# Altium schematic sheet 20210515-power.SchDoc
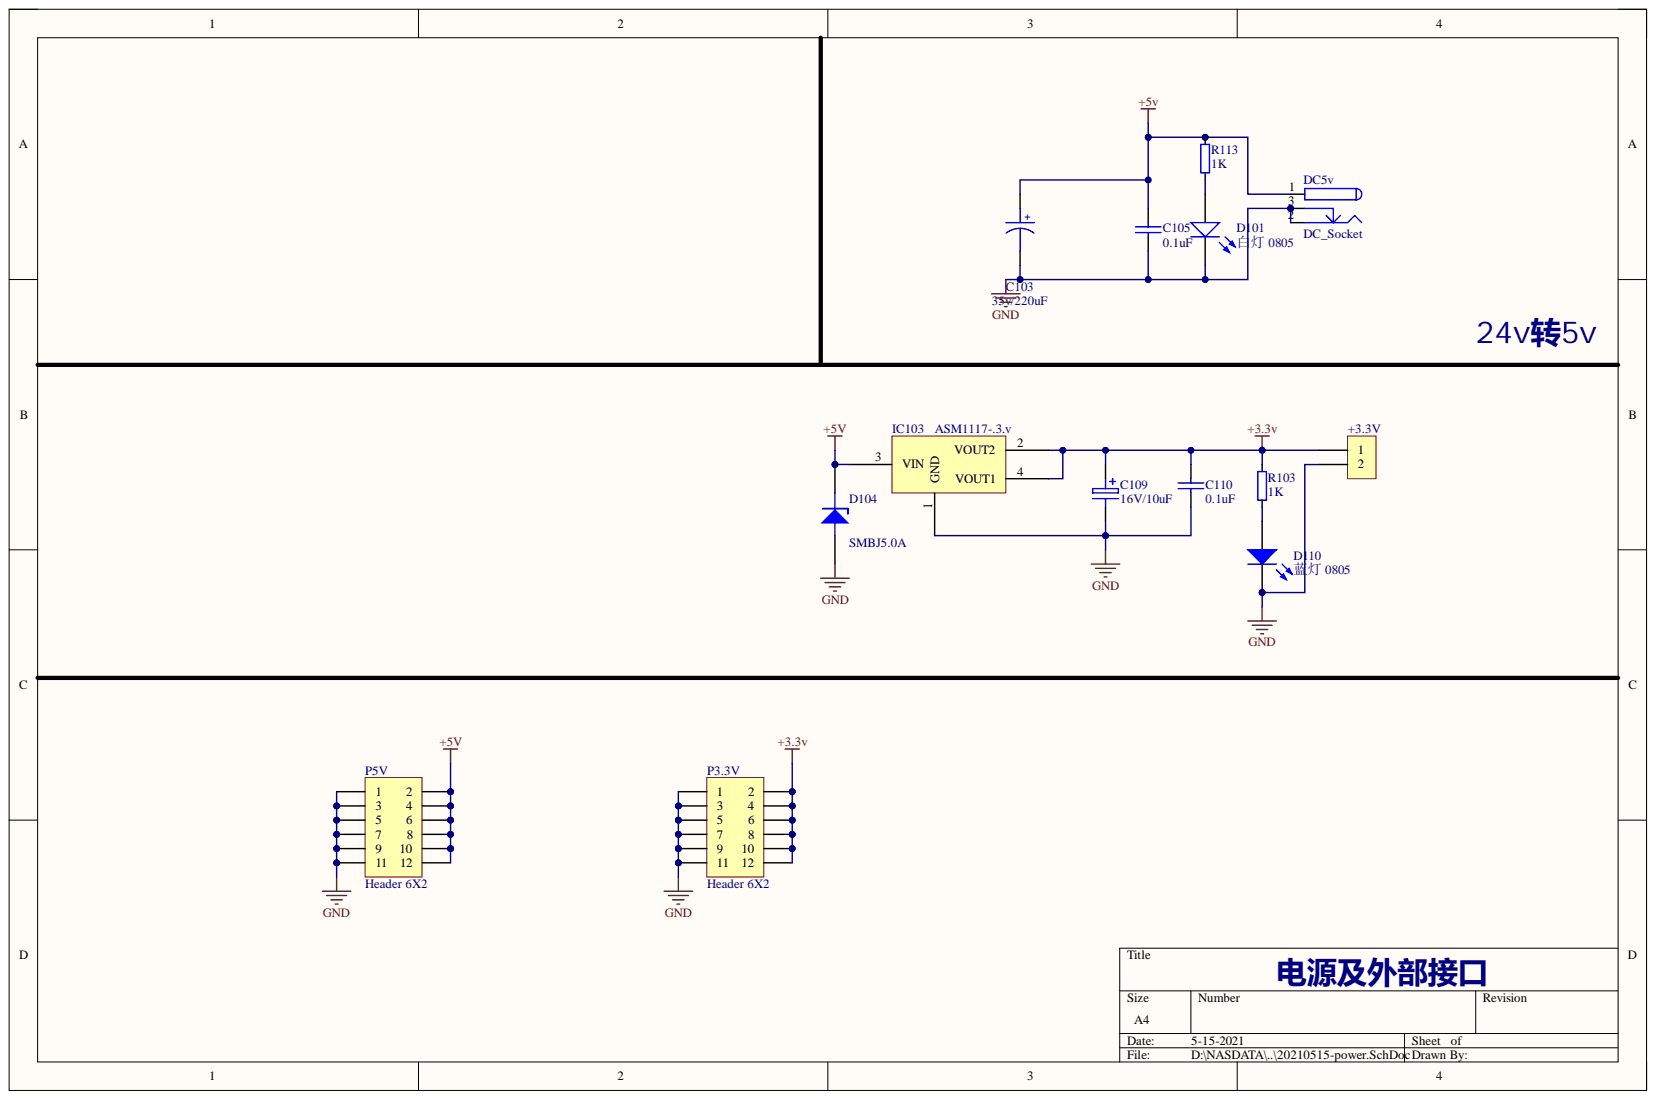
[diagram p0: 20210515-power.SchDoc]

## Parts
+3.3V  (no value)  [XH2/2.54mm/90]
C103  35v/220uF  [SMD-EC8X8X10]
C105  0.1uF  [C0805]
C109  16V/10uF  [SMD-EC6.3X6.3X10]
C110  0.1uF  [C0805]
D101  白灯 0805  [0805_LED_S1]
D104  SMBJ5.0A  [SMB]
D110  蓝灯 0805  [0805_LED_S1]
DC5v  DC_Socket  [DC-002]
IC103  ASM1117-.3.v  [SOT223]
P3.3V  Header 6X2  [HDR2X6]
P5V  Header 6X2  [HDR2X6]
R103  1K  [R0805]
R113  1K  [R0805]

## Nets
+3.3v: +3.3V.1 C109.1 C110.1 IC103.2(VOUT2) IC103.4(VOUT1) P3.3V.2 P3.3V.4 P3.3V.6 P3.3V.8 P3.3V.10 P3.3V.12 R103.2
+5v: C103.1 C105.1 D104.2 DC5v.1 IC103.3(VIN) P5V.2 P5V.4 P5V.6 P5V.8 P5V.10 P5V.12 R113.2
GND: +3.3V.2 C103.2 C105.2 C109.2 C110.2 D101.K D104.1 D110.K DC5v.2 DC5v.3 IC103.1(GND) P3.3V.1 P3.3V.3 P3.3V.5 P3.3V.7 P3.3V.9 P3.3V.11 P5V.1 P5V.3 P5V.5 P5V.7 P5V.9 P5V.11
NetD101_A: D101.A R113.1
NetD110_A: D110.A R103.1

## Library data of the parts
+3.3V = CON2: Connector; Component Kind: Standard; Library Name: 插件.SCHLIB
C103 = 电解电容: Component Kind: Standard; Library Name: LX.SchLib
C105 C110 = 贴片电容: Component Kind: Standard; Library Name: LX.SchLib
C109 = 钽电容: Component Kind: Standard; Library Name: LX.SchLib
D101 = 0805 白灯: 发光二极管; Component Kind: Standard; ComponentLink1Description: 供应商链接; ComponentLink1URL: http://www.szlcsc.com/product/details_35468.html; Library Name: Miscellaneous Devices LC.IntLib; Package: 0805; Supplier: LC; SuppliersPartNumber: C34499
D104 = 贴片稳压二极管: Component Kind: Standard; Library Name: LX.SchLib
D110 = 0805 蓝灯: 发光二极管; Component Kind: Standard; ComponentLink1Description: 供应商链接; ComponentLink1URL: http://www.szlcsc.com/product/details_2666.html; Library Name: Miscellaneous Devices LC.IntLib; Package: 0805; Supplier: LC; SuppliersPartNumber: C2293
IC103 = ASM1117: Component Kind: Standard; Library Name: LX.SchLib
P3.3V P5V = Header 6X2: Header, 6-Pin, Dual row; Component Kind: Standard; LatestRevisionDate: 17-Jul-2002; LatestRevisionNote: Re-released for DXP Platform.; Library Name: Miscellaneous Connectors.IntLib; Signal Integrity: Connector; Publisher: Altium Limited
R103 R113 = 贴片电阻: Component Kind: Standard; Library Name: LX.SchLib
DC5v = DC_Socket: Component Kind: Standard; Library Name: 电源插座.SchLib
